# Altium schematic sheet KC1_1.SchDoc(KC1_1)
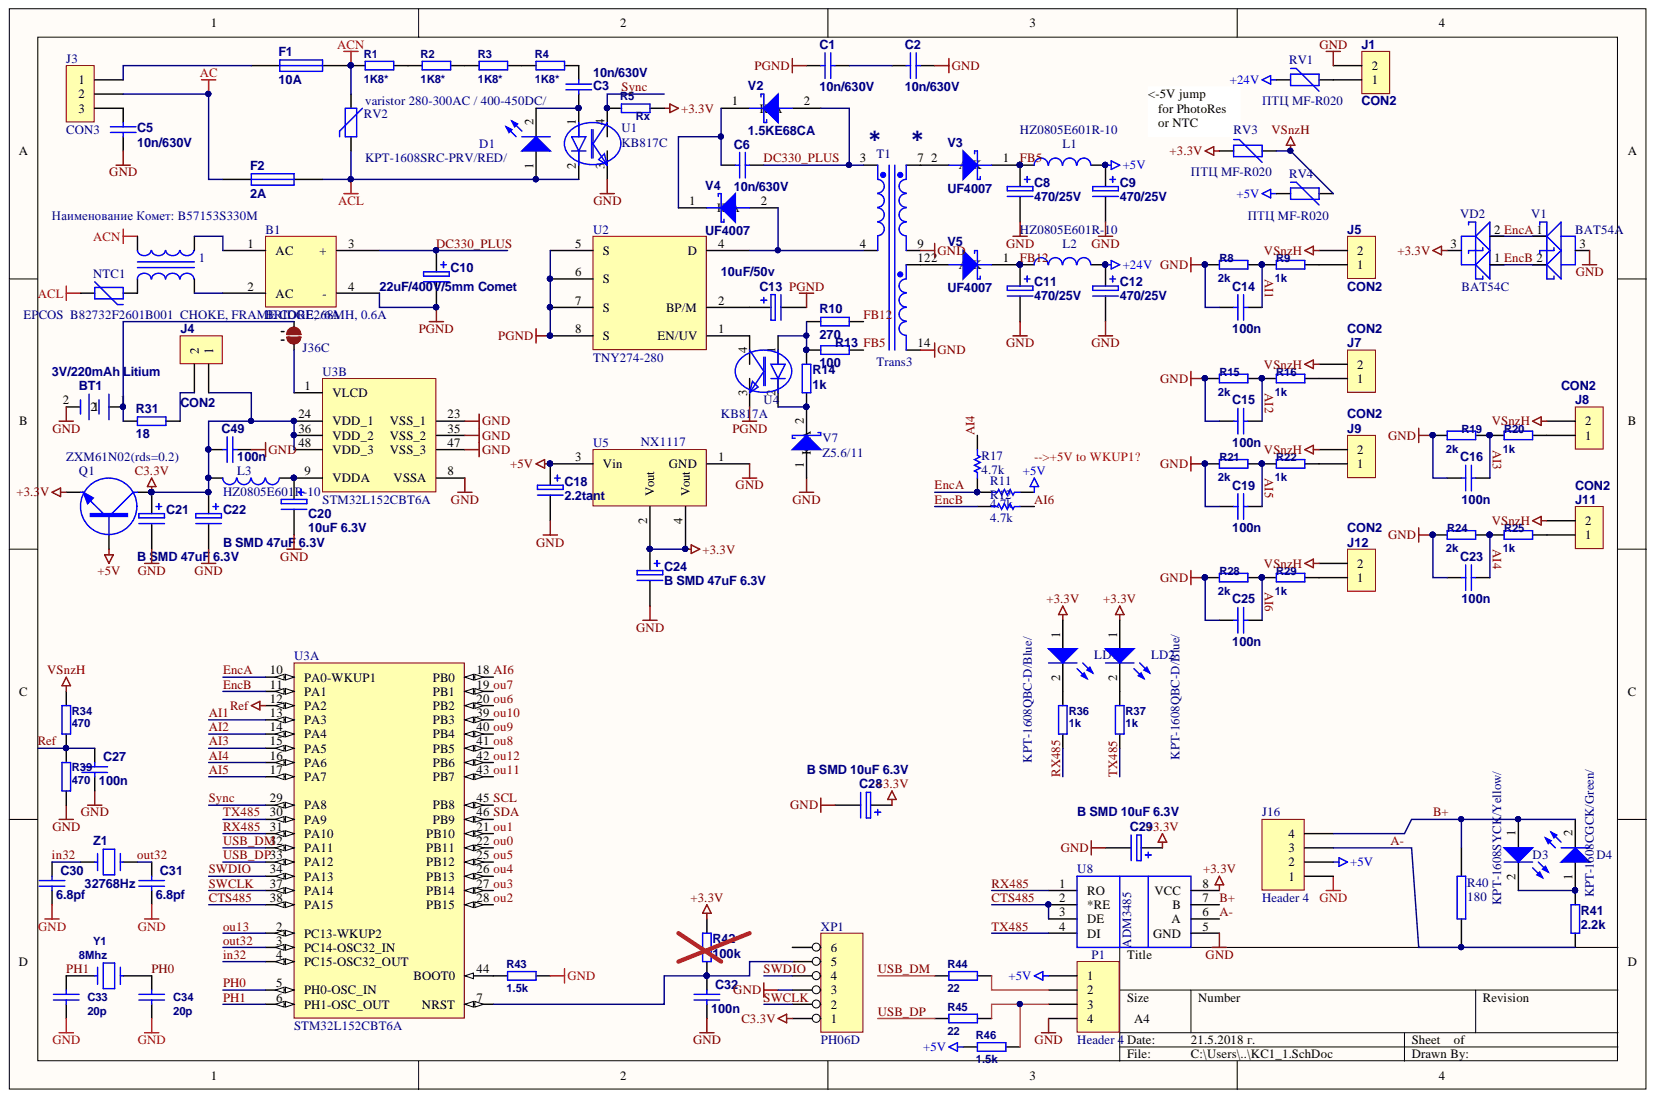
[diagram p0: KC1_1.SchDoc]

## Parts
1  EPCOS  B82732F2601B001  CHOKE, FRAME CORE, 68MH, 0.6A  [TR19x15p4]
B1  BRIDGE2/6A  [db107]
BT1  3V/220mAh Litium  [CR2032V]
C1  10n/630V  [C1206]
C2  10n/630V  [C1206]
C3  10n/630V  [C1206]
C5  10n/630V  [C1206]
C6  10n/630V  [C1206]
C8  470/25V  [PCAP8V]
C9  470/25V  [PCAP8V]
C10  22uF/400V/5mm Comet  [PCAP10V]
C11  470/25V  [PCAP7V]
C12  470/25V  [PCAP7V]
C13  10uF/50v  [PCAP5V]
C14  100n  [0805.PRT_0805.PRT]
C15  100n  [0805.PRT_0805.PRT]
C16  100n  [0805.PRT_0805.PRT]
C18  2.2tant  [1210p]
C19  100n  [0805.PRT_0805.PRT]
C20  10uF 6.3V  [0805C]
C21  B SMD 47uF 6.3V  [1210p]
C22  B SMD 47uF 6.3V  [1210p]
C23  100n  [0805.PRT_0805.PRT]
C24  B SMD 47uF 6.3V  [1210p]
C25  100n  [0805.PRT_0805.PRT]
C27  100n  [0805.PRT_0805.PRT]
C28  B SMD 10uF 6.3V  [1210p]
C29  B SMD 10uF 6.3V  [1210p]
C30  6.8pf  [0805.PRT_0805.PRT]
C31  6.8pf  [0805.PRT_0805.PRT]
C32  100n  [0805.PRT_0805.PRT]
C33  20p  [0805.PRT_0805.PRT]
C34  20p  [0805.PRT_0805.PRT]
C49  100n  [0603C]
D1  KPT-1608SRC-PRV/RED/  [0603D]
D3  KPT-1608SYCK/Yellow/  [0603D]
D4  KPT-1608CGCK/Green/  [0603D]
F1  10A  [FUSBG]
F2  2A  [FUSBG]
J1  CON2  [CON2-MINI]
J3  CON3  [CON3-MINI-5_00MM]
J4  CON2  [sip-2]
J5  CON2  [CON2-MINI]
J7  CON2  [CON2-MINI]
J8  CON2  [CON2-MINI]
J9  CON2  [CON2-MINI]
J11  CON2  [CON2-MINI]
J12  CON2  [CON2-MINI]
J16  Header 4  [SIP-4]
J36C  2 way net solder junction - Normally Open  [2waySolder_NO]  (value not drawn)  (no BOM)
L1  HZ0805E601R-10  [0805R]
L2  HZ0805E601R-10  [0805R]
L3  HZ0805E601R-10  [0805R]
LD1  KPT-1608QBC-D/Blue/  [0603D]
LD2  KPT-1608QBC-D/Blue/  [0603D]
NTC1  Наименование Комет: B57153S330M  [CAP4]
P1  Header 4  [HDR1X5]
Q1  ZXM61N02(rds=0.2)  [SOT-23-BC846-850-856-860]
R1  1K8*  [1206S]
R2  1K8*  [1206S]
R3  1K8*  [1206S]
R4  1K8*  [1206S]
R5  Rx  [0805r]
R8  2k  [0805R]
R9  1k  [0805R]
R10  270  [0805.PRT_0805.PRT]
R11  4.7k  [0805r]
R12  4.7k  [0805R]
R13  100  [0805.PRT_0805.PRT]
R14  1k  [0805.PRT_0805.PRT]
R15  2k  [0805R]
R16  1k  [0805R]
R17  4.7k  [0805R]
R19  2k  [0805R]
R20  1k  [0805R]
R21  2k  [0805R]
R22  1k  [0805R]
R24  2k  [0805R]
R25  1k  [0805R]
R28  2k  [0805R]
R29  1k  [0805R]
R31  18  [1206s]
R34  470  [0805R]
R36  1k  [0805r]
R37  1k  [0805r]
R39  470  [0805R]
R40  180  [0805.PRT_0805.PRT]
R41  2.2k  [0805r]
R42  100k  [0805.PRT_0805.PRT]
R43  1.5k  [0805.PRT_0805.PRT]
R44  22  [0805.PRT_0805.PRT]
R45  22  [0805.PRT_0805.PRT]
R46  1.5k  [0805.PRT_0805.PRT]
RV1  ПТЦ MF-R020  [resv]
RV2  varistor 280-300AC / 400-450DC/  [CAP4]
RV3  ПТЦ MF-R020  [resv]
RV4  ПТЦ MF-R020  [resv]
T1  Trans3  [TR_ER2793]
U1  KB817C  [DIP4]
U2  TNY274-280  [TNY277dip]
U3  STM32L152CBT6A  [STM-LQFP48_L]  (parts A,B)
U4  KB817A  [DIP4]
U5  NX1117  [sot-223b]
U8  ADM3485  [so-8]
V1  BAT54A  [BAT54A.PRT_BAT54A.PRT]
V2  1.5KE68CA  [diode5GV]
V3  UF4007  [DIODE5GV]
V4  UF4007  [diode4]
V5  UF4007  [DIODE5GV]
V7  Z5.6/11  [Z1W]
VD2  BAT54C  [BAT54A.PRT_BAT54A.PRT]
XP1  PH06D  [sip-6]
Y1  8Mhz  [XTAL-MINI]
Z1  32768Hz  [ZQ4L.PRT_ZQ4L.PRT]

## Nets
+3.3V: C24.1 C28.1 C29.1 LD1.1 LD2.1 Q1.E R5.1 R42.1 RV3.2 U5.2(Vout) U5.4(Vout) U8.8(VCC) VD2.3
+5V: C9.1 C18.1 J16.2 L1.2 P1.1 Q1.B R11.1 R46.1 RV4.2 U5.3(Vin)
+24V: C12.1 L2.2 RV1.2
AC: F2.2 J3.2
ACL: D1.1 F2.1 NTC1.1 RV2.2 U1.2
ACN: 1.2 F1.1 R1.2 RV2.1
C3.3V: C21.1 C22.1 C49.1 J4.1 L3.2 Q1.C U3.24(VDD_1) U3.36(VDD_2) U3.48(VDD_3) XP1.1
GND: BT1.2 C2.1 C5.1 C8.2 C9.2 C11.2 C12.2 C14.1 C15.1 C16.1 C18.2 C19.1 C20.2 C21.2 C22.2 C23.1 C24.2 C25.1 C27.2 C28.2 C29.2 C30.2 C31.2 C32.2 C33.2 C34.2 C49.2 J1.2 J16.1 P1.4 R8.2 R15.2 R19.2 R21.2 R24.2 R28.2 R39.2 R43.2 T1.9 T1.14(FB5) U1.3 U3.8(VSSA) U3.23(VSS_1) U3.35(VSS_2) U3.47(VSS_3) U5.1(GND) U8.5(GND) V1.3 V7.1 XP1.3
PGND: B1.4(-) C1.2 C10.2 C13.2 U2.5(S) U2.6(S) U2.7(S) U2.8(S) U4.3
VSNZH: J5.2 J7.2 J8.2 J9.2 J11.2 J12.2 R34.1 RV3.1 RV4.1
A-: J16.3 R40.1 R41.1 U8.6(A)
AI1: C14.2 R8.1 R9.2 U3.13(PA3)
AI2: C15.2 R15.1 R16.2 U3.14(PA4)
AI3: C16.2 R19.1 R20.2 U3.15(PA5)
AI4: C23.2 R17.1 R24.1 R25.2 U3.16(PA6)
AI5: C19.2 R21.1 R22.2 U3.17(PA7)
AI6: C25.2 R12.1 R28.1 R29.2 U3.18(PB0)
B+: D3.1 D4.2 J16.4 R40.2 U8.7(B)
CTS485: U3.38(PA15) U8.2(*RE) U8.3(DE)
DC330_PLUS: B1.3(+) C6.1 C10.1 T1.3 V2.2
ENCA: R11.2 R17.2 U3.10(PA0-WKUP1) V1.1 VD2.2
ENCB: R12.2 U3.11(PA1) V1.2 VD2.1
FB5: C8.1 L1.1 R13.2 V3.1
FB12: C11.1 L2.1 R10.2 V5.1
IN32: C30.1 U3.4(PC15-OSC32_OUT) Z1.2
OU0: U3.22(PB11)
OU1: U3.21(PB10)
OU2: U3.28(PB15)
OU3: U3.27(PB14)
OU4: U3.26(PB13)
OU5: U3.25(PB12)
OU6: U3.20(PB2)
OU7: U3.19(PB1)
OU8: U3.41(PB5)
OU9: U3.40(PB4)
OU10: U3.39(PB3)
OU11: U3.43(PB7)
OU12: U3.42(PB6)
OU13: U3.2(PC13-WKUP2)
OUT32: C31.1 U3.3(PC14-OSC32_IN) Z1.1
PH0: C34.1 U3.5(PH0-OSC_IN) Y1.2
PH1: C33.1 U3.6(PH1-OSC_OUT) Y1.1
REF: C27.1 R34.2 R39.1 U3.12(PA2)
RX485: R36.2 U3.31(PA10) U8.1(RO)
SCL: U3.45(PB8)
SDA: U3.46(PB9)
SWCLK: U3.37(PA14) XP1.2
SWDIO: U3.34(PA13) XP1.4
SYNC: R5.2 U1.4 U3.29(PA8)
TX485: R37.2 U3.30(PA9) U8.4(DI)
USB_DM: R44.2 U3.32(PA11)
USB_DP: R45.2 U3.33(PA12)
Net1_1: 1.1 NTC1.2
Net1_3: 1.3 B1.2(AC)
Net1_4: 1.4 B1.1(AC)
NetBT1_1: BT1.1 J36C.1 R31.2
NetC1_1: C1.1 C2.2
NetC3_1: C3.1 D1.2 U1.1
NetC3_2: C3.2 R4.1
NetC5_2: C5.2 J3.3
NetC6_2: C6.2 V2.1 V4.1
NetC13_1: C13.1 U2.2(BP/M)
NetC20_1: C20.1 L3.1 U3.9(VDDA)
NetC32_1: C32.1 R42.2 U3.7(NRST) XP1.5
NetD3_2: D3.2 D4.1 R41.2
NetF1_2: F1.2 J3.1
NetJ1_1: J1.1 RV1.1
NetJ4_2: J4.2 R31.1
NetJ5_1: J5.1 R9.1
NetJ7_1: J7.1 R16.1
NetJ8_1: J8.1 R20.1
NetJ9_1: J9.1 R22.1
NetJ11_1: J11.1 R25.1
NetJ12_1: J12.1 R29.1
NetJ36C_2: J36C.2 U3.1(VLCD)
NetLD1_2: LD1.2 R36.1
NetLD2_2: LD2.2 R37.1
NetP1_2: P1.2 R44.1
NetP1_3: P1.3 R45.1 R46.2
NetR1_1: R1.1 R2.2
NetR2_1: R2.1 R3.2
NetR3_1: R3.1 R4.2
NetR10_1: R10.1 R13.1 R14.2 U4.1
NetR14_1: R14.1 U4.2 V7.2
NetR43_1: R43.1 U3.44(BOOT0)
NetT1_4: T1.4 U2.4(D) V4.2
NetT1_7: T1.7 V3.2
NetT1_12: T1.12 V5.2
NetU2_1: U2.1(EN/UV) U4.4

Not connected: XP1 6

## Library data of the parts
1 = TRANS1: Component Kind: Standard; Library Name: Device.SCHLIB
B1 = BRIDGE2: Component Kind: Standard; Library Name: Device.SCHLIB
BT1 = BATTERY: Component Kind: Standard
C1-C3 C5 C6 C14-C16 C19 C23 C25 C27 C30-C34 C49 = CAP: Capacitor; Component Kind: Standard
C8-C13 C18 C20-C22 C24 C28 C29 = ELECTRO1: Electrolytic Capacitor; Component Kind: Standard
D1 D3 D4 LD1 LD2 = LED2: Typical RED, GREEN, YELLOW, AMBER GaAs LED; Component Kind: Standard; Library Name: Miscellaneous Devices.IntLib; Published: 8-Jun-2000; Revision: July-2002: Re-released for DXP Platform.; Publisher: Altium Limited
F1 F2 = FUSE1: Fuse; Component Kind: Standard
J1 J4 J5 J7-J9 J11 J12 = CON2: Connector; Component Kind: Standard
J3 = CON3: Connector; Component Kind: Standard
J16 P1 = Header 4: Header, 4-Pin; Component Kind: Standard; LatestRevisionDate: 17-Jul-2002; LatestRevisionNote: Re-released for DXP Platform.; Library Name: Miscellaneous Connectors.IntLib; Signal Integrity: Connector; Publisher: Altium Limited
J36C = 2waySolderNO: ComponentLink1Description: Source; ComponentLink1URL: http://www.edatools.cz/addons; Description: 2 way net solder junction - Normally Open; Library Name: NetTieLib.IntLib
L1-L3 = Inductor: Value: 100uH; Component Kind: Standard; Description: Inductor; Library Name: Miscellaneous Devices.IntLib; Published: 8-Jun-2000; Revision: July-2002: Re-released for DXP Platform.; Publisher: Altium Limited
NTC1 RV1-RV4 = VARISTOR: Component Kind: Standard; Library Name: Device.SCHLIB
Q1 = NPN: Component Kind: Standard
R1-R5 R8 R9 R15 R16 R19-R22 R24 R25 R28 R29 R34 R36 R37 R39 = RESMIN: Component Kind: Standard
R10 R13 R14 R31 R41-R46 = RES: Component Kind: Standard
R11 R12 R17 = Res1: Resistor; Value: 1K; Component Kind: Standard; Library Name: Miscellaneous Devices.IntLib; Published: 8-Jun-2000; Revision: July-2002: Re-released for DXP Platform.; Signal Integrity: Res; Simulation: RESISTOR; Publisher: Altium Limited
R40 = RES2: Component Kind: Standard
T1 = Trans3: Three-winding Transformer (Non-Ideal); Sim Note: Enter the turns ratios, Core loss resistance, Magnetising Reactance, Leakage Reactances and Resistances referred to the primary side into the parameter fields.; Component Kind: Standard; LatestRevisionDate: 17-Jul-2002; LatestRevisionNote: Re-released for DXP Platform.; Library Name: Miscellaneous Devices.IntLib; PackageDescription: Transformer; 6 Leads; PackageReference: TRF_6; Published: 25-Jan-2001; Simulation: NI3WTRANS; Publisher: Altium Limited
U1 U4 = OPT2X2_1: Component Kind: Standard; Library Name: OptoRu.SCHLIB
U2 = TNY277: Component Kind: Standard; Library Name: StabsRu.SCHLIB
U3 = STM32L152CBT6A: ARM Cortex-M3 32-bit MCU, 128 KB Flash, 16 KB Internal RAM, 37 I/Os, 48-pin LQFP, -40 to 85 degC, Tray; D/A Converter: 2x12-bit (2 Channel); Data E2PROM nom (B): 4096; FLASH Size (Prog) (kB): 128; I/Os (High Current): 37; Internal RAM Size (kB): 16; Operating Frequency(F) (Processor speed) (MHz): 32; Supply Voltage(Vcc) min (V): 1.65; Component Kind: Standard; ComponentLink1Description: Manufacturer URL; ComponentLink1URL: http://www.st.com/web/en/home.html; ComponentLink2Description: Datasheet; ComponentLink2URL: http://www.st.com/st-web-ui/static/active/en/resource/technical/document/datasheet/CD00277537.pdf; ComponentLink3Description: Package Specification; ComponentLink3URL: http://www.st.com/st-web-ui/static/active/en/resource/technical/document/datasheet/DM00058181.pdf; Core: ARM Cortex-M3; DatasheetVersion: Rev. 8; Library Name: STMicroelectronics STM32 L1.IntLib; Mounting Technology: Surface Mount; PackageDescription: 48-Pin Low Profile Quad Flat Package, 7 x 7 mm Body, 0.5 mm Pitch; PackageReference: LQFP48; PackageVersion: Rev. 6, 06/2013; Packing: Tray; PartNumber: STM32L152CBT6A; Supplier 1: Mouser; Supplier Part Number 1: N/A
U5 = LM7824: Component Kind: Standard; Library Name: StabsRu.SCHLIB
U8 = ADM485: Component Kind: Standard
V1 = DorZR_SOT23: Component Kind: Standard; Library Name: Igla.SCHLIB
V2-V5 = DIODE SCHOTTKY: Schottky Diode; Component Kind: Standard
V7 = Diode: Note: Pin 2 is not connected and not drawn.; Component Kind: Standard; ComponentLink1Description: Manufacturer Link; ComponentLink1URL: http://www.infineon.com/; ComponentLink2Description: Datasheet; ComponentLink2URL: http://www.infineon.com/upload/Document/cmc_upload/documents/009/363/bat17series.pdf; DatasheetVersion: Oct-1999; Description: Diode; LatestRevisionDate: 29-May-2009; LatestRevisionNote: IPC-7351 Footprint Added.; PackageDescription: 3-Pin SOT-23 Package 0.95 mm Pitch, 2.5 mm Lead Span; PackageReference: SOT-23; PackageVersion: Sep-2001; Published: 21-Mar-2003; Publisher: Altium Limited
VD2 = DorZ_SOT23: Component Kind: Standard; Library Name: Igla.SCHLIB
XP1 = HEADER 6: Component Kind: Standard
Y1 Z1 = CRYSTAL: Component Kind: Standard
  Y1: Description: Crystal
